# Altium schematic sheet lv_power_motor.SchDoc(lv_power_motor)
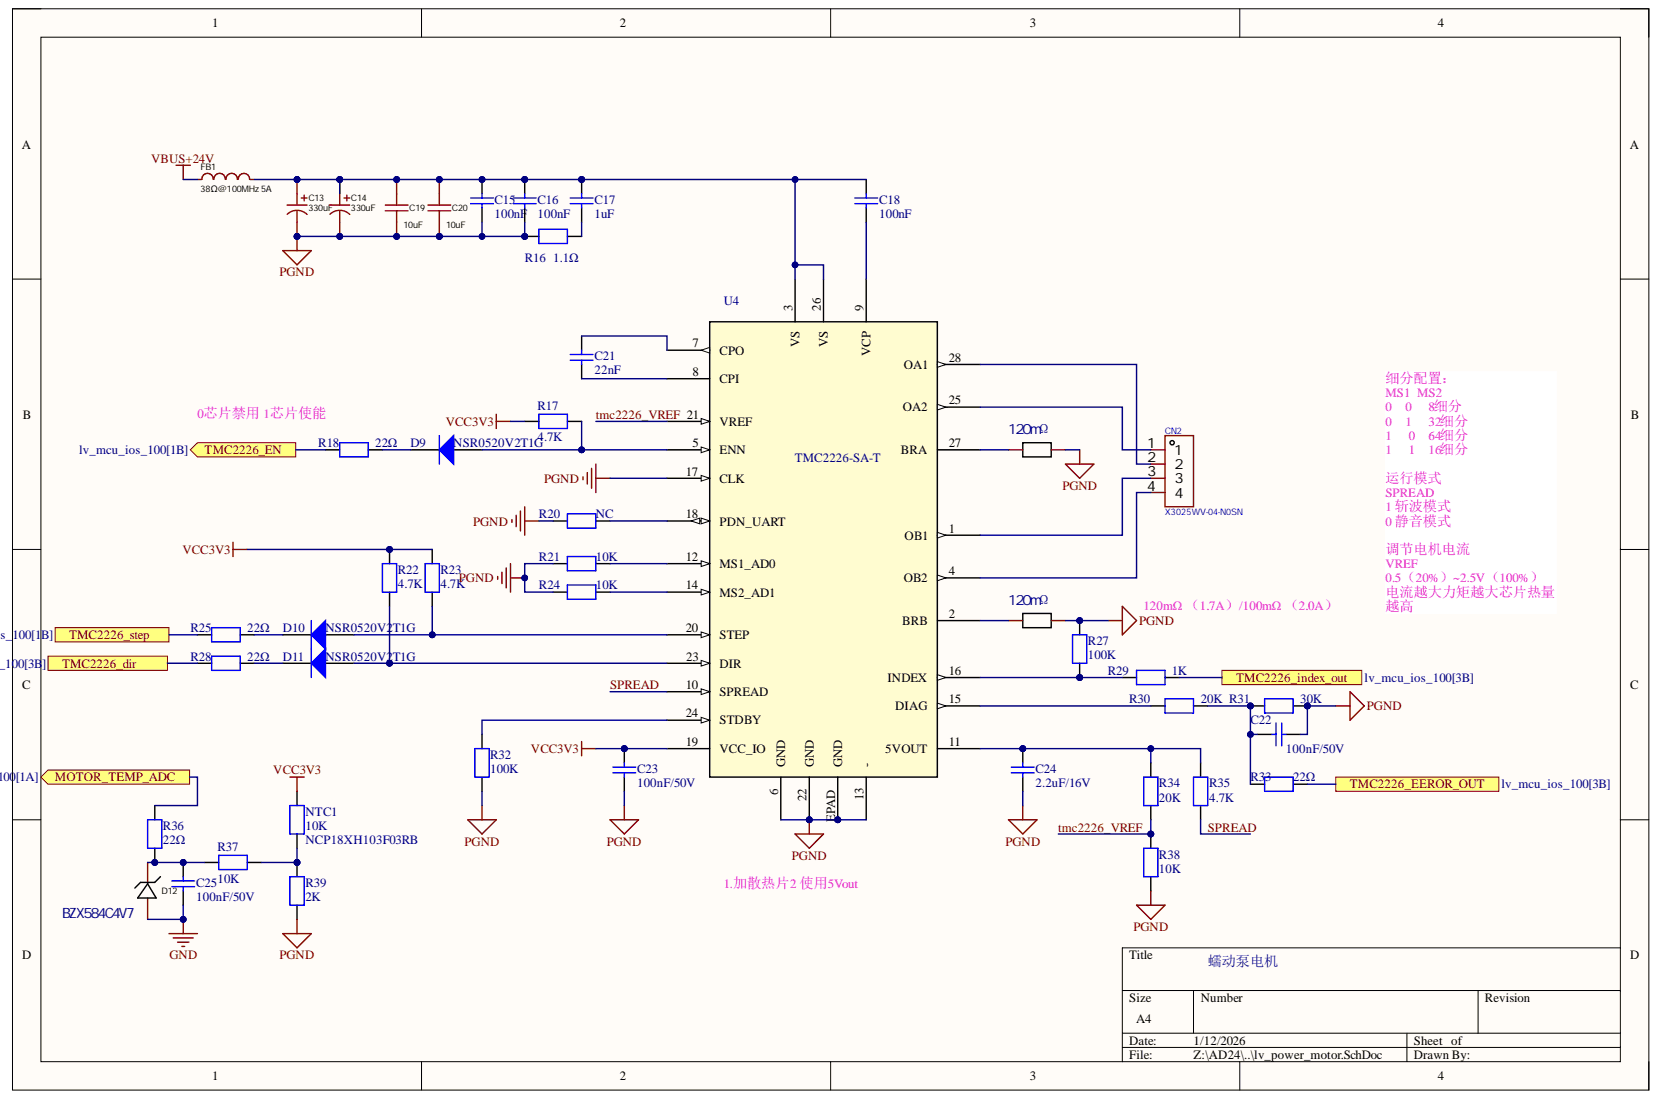
[diagram p0: lv_power_motor.SchDoc]

## Parts
C13  330uF  [CAP-SMD_BD10.0-L10.3-W10.3-LS10.9-FD]
C14  330uF  [CAP-SMD_BD10.0-L10.3-W10.3-LS10.9-FD]
C15  100nF  [C0603]
C16  100nF  [C0603]
C17  1uF  [C1210]
C18  100nF  [C0603]
C19  10uF  [C2220]
C20  10uF  [C2220]
C21  22nF  [C0603]
C22  100nF/50V  [C0402]
C23  100nF/50V  [C0402]
C24  2.2uF/16V  [C0603]
C25  100nF/50V  [C0402]
CN2  X3025WV-04-N0SN  [CONN-TH_4P-P3.00_X3025WV-04-N0SN]
D9  NSR0520V2T1G  [SOD-523F_L1.2-W0.8-LS1.7-RD]
D10  NSR0520V2T1G  [SOD-523F_L1.2-W0.8-LS1.7-RD]
D11  NSR0520V2T1G  [SOD-523F_L1.2-W0.8-LS1.7-RD]
D12  BZX584C4V7  [SOD-523F_L1.2-W0.8-LS1.7-RD]
FB1  LCSC Part Name: 38Ω@100MHz 5A  [L1206]  (hidden comment: PZ3216D380-5R0TF)
NTC1  NCP18XH103F03RB  value 10K  [R0603]
R16  1.1Ω  [R0603]
R17  4.7K  [R0603]
R18  22Ω  [R0402]
R19  120mΩ  [RES-SMD_L6.4-W3.2_C500733]
R20  NC  [R0402]
R21  10K  [R0402]
R22  4.7K  [R0402]
R23  4.7K  [R0402]
R24  10K  [R0402]
R25  22Ω  [R0402]
R26  120mΩ  [RES-SMD_L6.4-W3.2_C500733]
R27  100K  [R0402]
R28  22Ω  [R0402]
R29  1K  [R0402]
R30  20K  [R0402]
R31  30K  [R0402]
R32  100K  [R0603]
R33  22Ω  [R0402]
R34  20K  [R0402]
R35  4.7K  [R0402]
R36  22Ω  [R0402]
R37  10K  [R0402]
R38  10K  [R0402]
R39  2K  [R0402]
U4  TMC2226-SA-T  [HTSSOP_26-SA-T_TRI]

## Ports
MOTOR_TEMP_ADC, TMC2226_dir, TMC2226_EEROR_OUT, TMC2226_EN, TMC2226_index_out, TMC2226_step

## Nets
GND: C25.2 D12.2
PGND: C13.2 C14.2 C15.1 C16.1 C19.2 C20.2 C22.2 C23.2 C24.2 R16.2 R19.2 R20.2 R21.1 R24.1 R26.2 R27.1 R31.1 R32.1 R38.2 R39.1 U4.6(GND) U4.13(-) U4.17(CLK) U4.22(GND) U4.EPAD(GND)
VBUS+24V: FB1.1
VCC3V3: C23.1 NTC1.2 R17.2 R22.1 R23.1 U4.19(VCC_IO)
MOTOR_TEMP_ADC: R36.2 ; port MOTOR_TEMP_ADC
SPREAD: R35.2 U4.10(SPREAD)
TMC2226_dir: R28.1 ; port TMC2226_dir
TMC2226_EEROR_OUT: R33.2 ; port TMC2226_EEROR_OUT
TMC2226_EN: R18.1 ; port TMC2226_EN
TMC2226_index_out: R29.1 ; port TMC2226_index_out
TMC2226_step: R25.1 ; port TMC2226_step
tmc2226_VREF: R34.2 R38.1 U4.21(VREF)
NetC13_1: C13.1 C14.1 C15.2 C16.2 C17.2 C18.2 C19.1 C20.1 FB1.2 U4.3(VS) U4.26(VS)
NetC17_1: C17.1 R16.1
NetC18_1: C18.1 U4.9(VCP)
NetC21_1: C21.1 U4.8(CPI)
NetC21_2: C21.2 U4.7(CPO)
NetC22_1: C22.1 R30.1 R31.2 R33.1
NetC24_1: C24.1 R34.1 R35.1 U4.11(5VOUT)
NetC25_1: C25.1 D12.1 R36.1 R37.2
NetCN2_1: CN2.1 U4.25(OA2)
NetCN2_2: CN2.2 U4.28(OA1)
NetCN2_3: CN2.3 U4.1(OB1)
NetCN2_4: CN2.4 U4.4(OB2)
NetD9_1: D9.1 R18.2
NetD9_2: D9.2 R17.1 U4.5(ENN)
NetD10_1: D10.1 R25.2
NetD10_2: D10.2 R23.2 U4.20(STEP)
NetD11_1: D11.1 R28.2
NetD11_2: D11.2 R22.2 U4.23(DIR)
NetNTC1_1: NTC1.1 R37.1 R39.2
NetR19_1: R19.1 U4.27(BRA)
NetR20_1: R20.1 U4.18(PDN_UART)
NetR21_2: R21.2 U4.12(MS1_AD0)
NetR24_2: R24.2 U4.14(MS2_AD1)
NetR26_1: R26.1 U4.2(BRB)
NetR27_2: R27.2 R29.2 U4.16(INDEX)
NetR30_2: R30.2 U4.15(DIAG)
NetR32_2: R32.2 U4.24(STDBY)

## Library data of the parts
C13 C14 = HV1V337M1010PZ: 330uF, 35V, 20%; Manufacturer: HONOR(荣誉); Add into BOM: yes; L: 10mm; Component Kind: Standard; Convert to PCB: yes; Datasheet: https://atta.szlcsc.com/upload/public/pdf/source/20240802/61683F5000C7CFED5606ABBE3CFBE0F5.pdf; Device: HV1V337M1010PZ; JLCPCB Part Class: Extended Part; LCSC Part Name: 330uF ±20% 35V; Library Name: HiPin_Library_AD.IntLib; Manufacturer Part: HV1V337M1010PZ; Operating Temperature: -55℃~+105℃; Rated Voltage: 35V; Supplier: LCSC; Supplier Footprint: SMD,D10xL10mm; Supplier Part: C5246558; Symbol: HV1V337M1010PZ; Tolerance: ±20%; Value: 330uF; φD: 10mm
C15 C16 C18 = Capacitor_1: 50V, X7R, 10%; Manufacturer: muRata; Manufacturer_Part_Number: GRM188R71H104KA93D; Component Kind: Standard; ComponentLink1Description: Yageo_Capacitor_X5R-series.pdf; ComponentLink1URL: http://tunmcdsvn.tun.st.com/Component_Datasheet_Library/Trunk/Capacitors/Yageo_Multilayer_capacitor_X5R_series_100pF_to_100uF; Library Name: HiPin_Library_AD.IntLib
C17 = Capacitor_3: 50V, X7R, 10%; Manufacturer: YAGEO; Manufacturer_Part_Number: CC1210KKX7R9BB105; Component Kind: Standard; Library Name: HiPin_Library_AD.IntLib
C19 C20 = FS55X106K500EGG: Manufacturer: PSA(信昌电陶); Add into BOM: yes; Temperature Coefficient: X7R; Tolerance: ±10%; Voltage Rated: 50V; Component Kind: Standard; Convert to PCB: yes; Datasheet: https://atta.szlcsc.com/upload/public/pdf/source/20190723/C381466_B1CCDB289216E7EE775C98769E222CFD.pdf; Device: FS55X106K500EGG; JLCPCB Part Class: Extended Part; LCSC Part Name: 10uF ±10% 50V; Library Name: HiPin_Library_AD.IntLib; Manufacturer Part: FS55X106K500EGG; Supplier: LCSC; Supplier Footprint: 2220; Supplier Part: C153034; Symbol: FS55X106K500EGG; Value: 10uF
C21 = Capacitor_1: 50V, X7R, 10%; Manufacturer: muRata; Manufacturer_Part_Number: GCJ188R71H223KA01D; Component Kind: Standard; ComponentLink1Description: Yageo_Capacitor_X5R-series.pdf; ComponentLink1URL: http://tunmcdsvn.tun.st.com/Component_Datasheet_Library/Trunk/Capacitors/Yageo_Multilayer_capacitor_X5R_series_100pF_to_100uF; Library Name: HiPin_Library_AD.IntLib
C22 C23 C25 = Capacitor_2: 50V, X7R , 10%; Manufacturer: muRata; Manufacturer_Part_Number: GRM155R71H104KE14D; Component Kind: Standard; ComponentLink1Description: Datasheet; ComponentLink1URL: http://tunmcdsvn.tun.st.com/Component_Datasheet_Library/Trunk/Capacitors/Yageo_Multilayer_capacitor_X5R_series_100pF_to_100uF; Library Name: HiPin_Library_AD.IntLib
C24 = Capacitor_2: 16V, X7R , 10%; Manufacturer: YAGEO; Manufacturer_Part_Number: CC0603KRX7R7BB225; Component Kind: Standard; ComponentLink1Description: Datasheet; ComponentLink1URL: http://tunmcdsvn.tun.st.com/Component_Datasheet_Library/Trunk/Capacitors/Yageo_Multilayer_capacitor_X5R_series_100pF_to_100uF; Library Name: HiPin_Library_AD.IntLib
CN2 = X3025WV-04-N0SN: 插针结构1x4P,间距3mm.安装方式直插  配套:针座X3025WV-04-N0SN ,胶壳X3025HM-04-N2 ,端子X3025TF-PSN; Manufacturer: XKB Connection(中国星坤); Add into BOM: yes; Current Rating: 5A; Number of PINs Per Row: 4; Pins Structure: 1x4P; Pitch: 3mm; Component Kind: Standard; Contact Material: 黄铜; Contact Plating: 锡; Convert to PCB: yes; Datasheet: https://item.szlcsc.com/datasheet/X3025WV-04-N0SN/639847.html; Device: X3025WV-04-N0SN; JLCPCB Part Class: Extended Part; LCSC Part Name: 1x4P 间距:3mm 直插; Library Name: HiPin_Library_AD.IntLib; Manufacturer Part: X3025WV-04-N0SN; Mounting Type: 直插; Number of Pins: 4P; Number of Rows: 1; Operating Temperature: -; PCB3D: CONN-TH_4P-P3.00_X3025WV-04-N0SN; Reference Series: -; Row Spacing: -; Supplier: LCSC; Supplier Footprint: 插件,P=3mm; Supplier Part: C609842; Symbol: X3025WV-04-N0SN
D9-D11 = 1N4148: Component Kind: Standard; Library Name: HiPin_Library_AD.IntLib
D12 = LM5Z3V6T1G: 4.8~5.4V, 200mW; Power Dissipation: 500mW; Component Kind: Standard; Library Name: HiPin_Library_AD.IntLib; Manufacturer Part: BZX584C4V7; Supplier Footprint: SOD-123; Tolerance: -
FB1 = BLM31PG601SN1L: Manufacturer: Sunlord(顺络); Add into BOM: yes; Circuits: 1; Current Rating: 1.5A; DC Resistance: 80mΩ; Impedance @ Frequency: 600Ω@100MHz; Tolerance: ±25%; Component Kind: Standard; Convert to PCB: yes; Datasheet: https://atta.szlcsc.com/upload/public/pdf/source/20180326/C88997_FE7208E239BA92CF7577D85CF2703D30.pdf; Device: BLM31PG601SN1L; JLCPCB Part Class: Extended Part; Library Name: HiPin_Library_AD.IntLib; Manufacturer Part: PZ3216D380-5R0TF; Supplier: LCSC; Supplier Footprint: 1206; Supplier Part: C16902; Symbol: BLM31PG601SN1L
NTC1 = RES: 1% 3380K; Component Kind: Standard; Library Name: HiPin_Library_AD.IntLib
R16-R18 R20 R22 R23 R25 R27-R36 R39 = RES: 1%; Value: 10K; Component Kind: Standard; Library Name: HiPin_Library_AD.IntLib
R19 R26 = PA2512FKE070R005E: 120mΩ，1W，1%; Manufacturer: YAGEO(国巨); Manufacturer Part: RL2512JK-070R12L; Component Kind: Standard; Library Name: HiPin_Library_AD.IntLib; PCB3D: RES-SMD_L6.4-W3.2_C500733
R21 R24 R37 R38 = RES: 1%; Component Kind: Standard; Library Name: HiPin_Library_AD.IntLib; Value: 10K
U4 = TMC2226-SA-T: No Description Available; Mfr_Name: Analog Devices Inc; Component Kind: Standard; Library Name: HiPin_Library_AD.IntLib; RefDes: RefDes; Type: Type
